# Altium schematic sheet XCore407I.SchDoc(XCore407I)
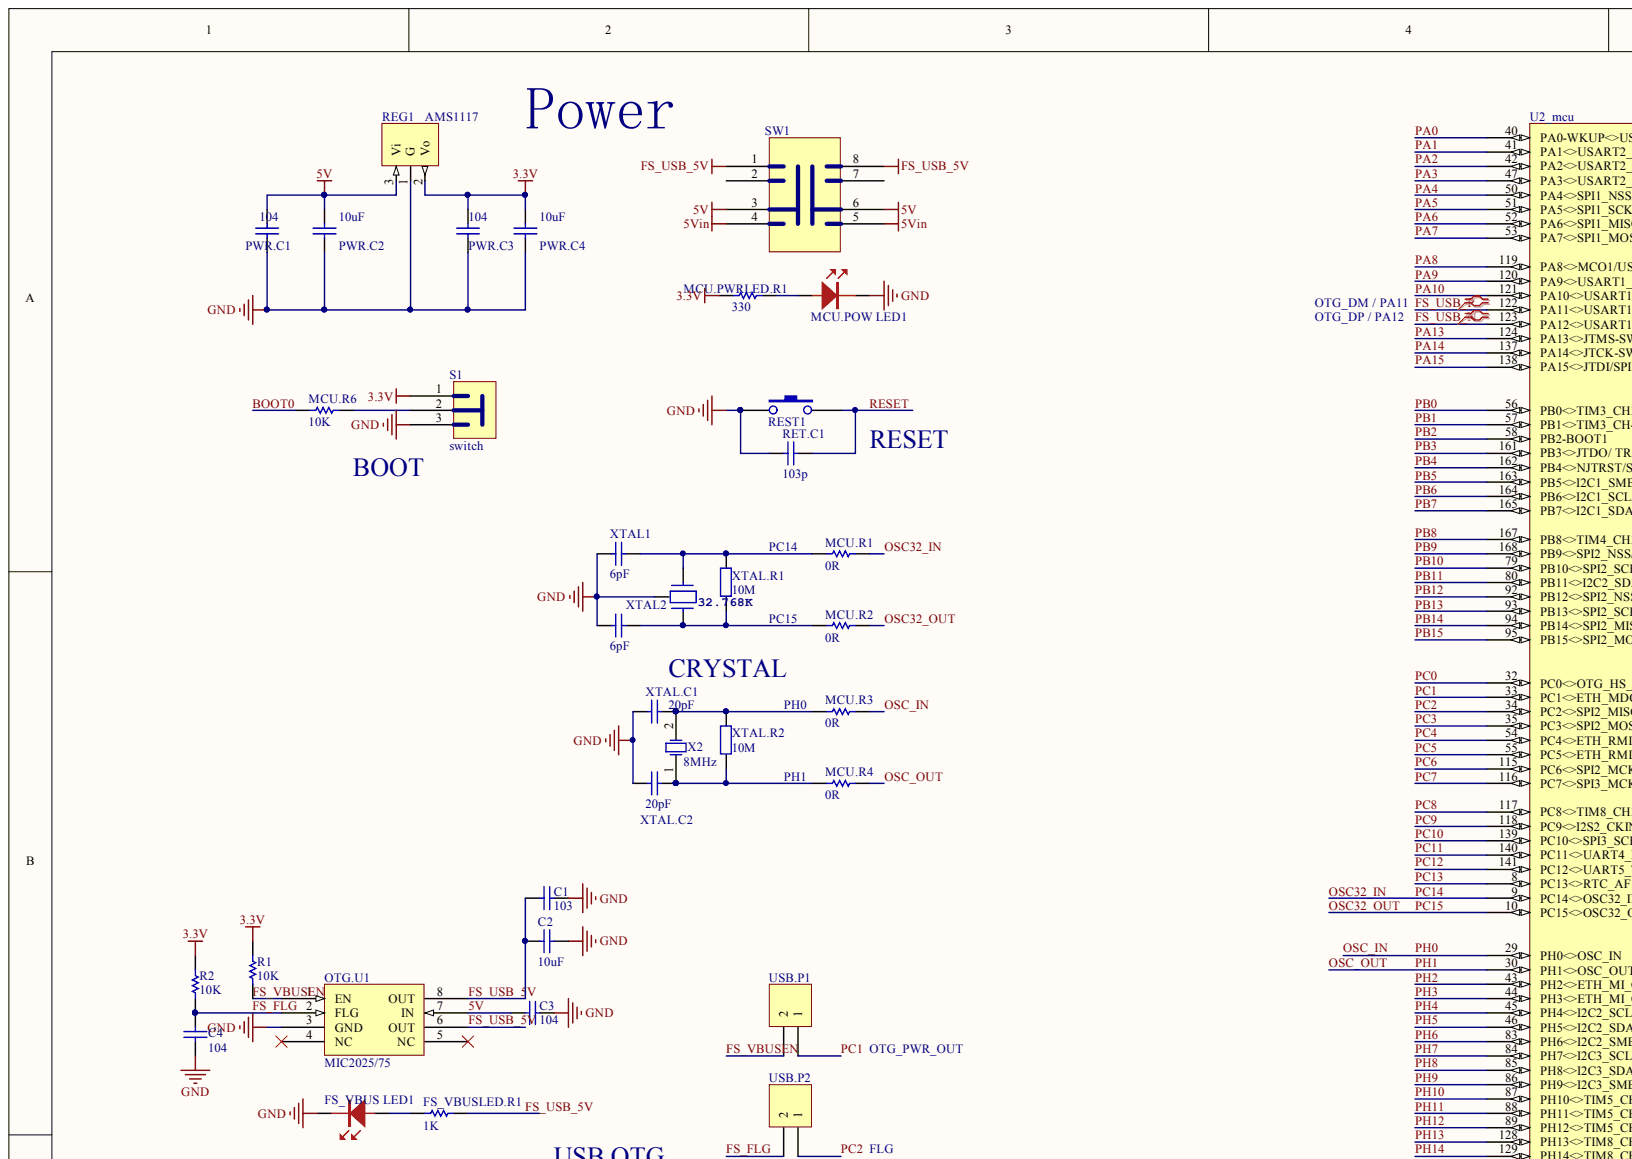
[diagram p0: XCore407I.SchDoc, top left]
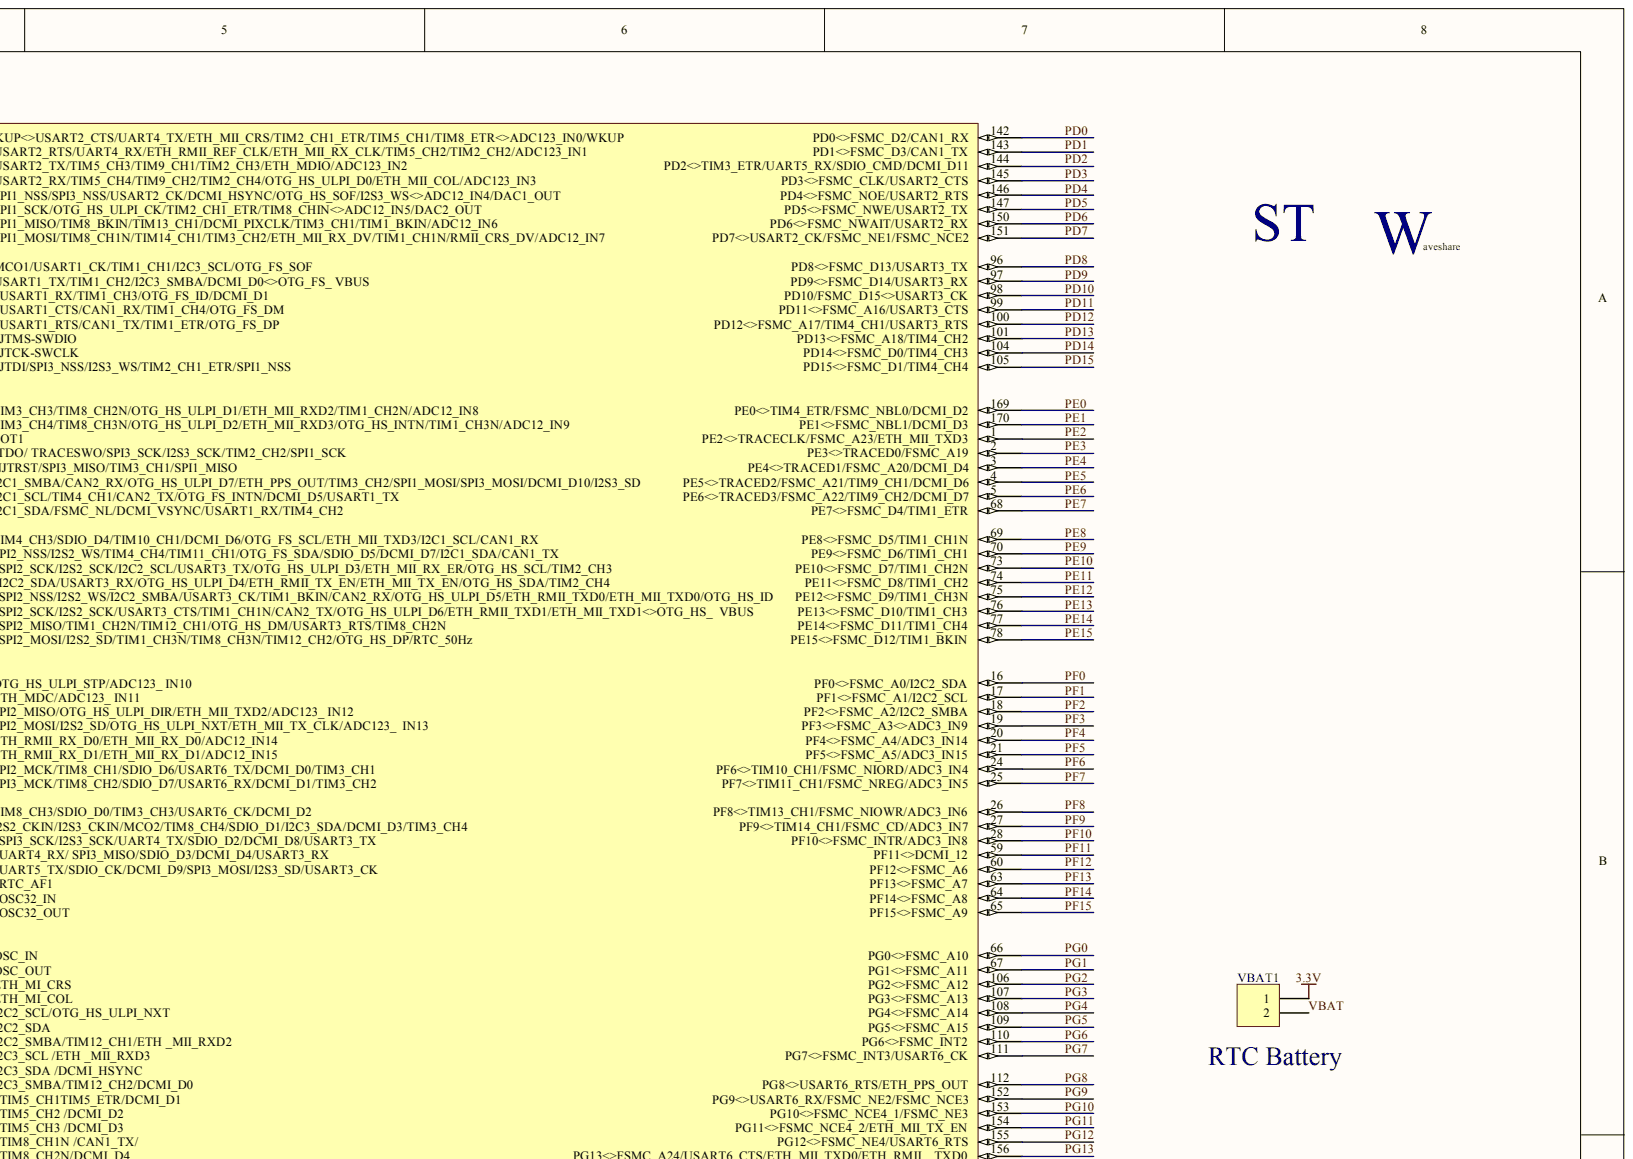
[diagram p1: XCore407I.SchDoc, top right]
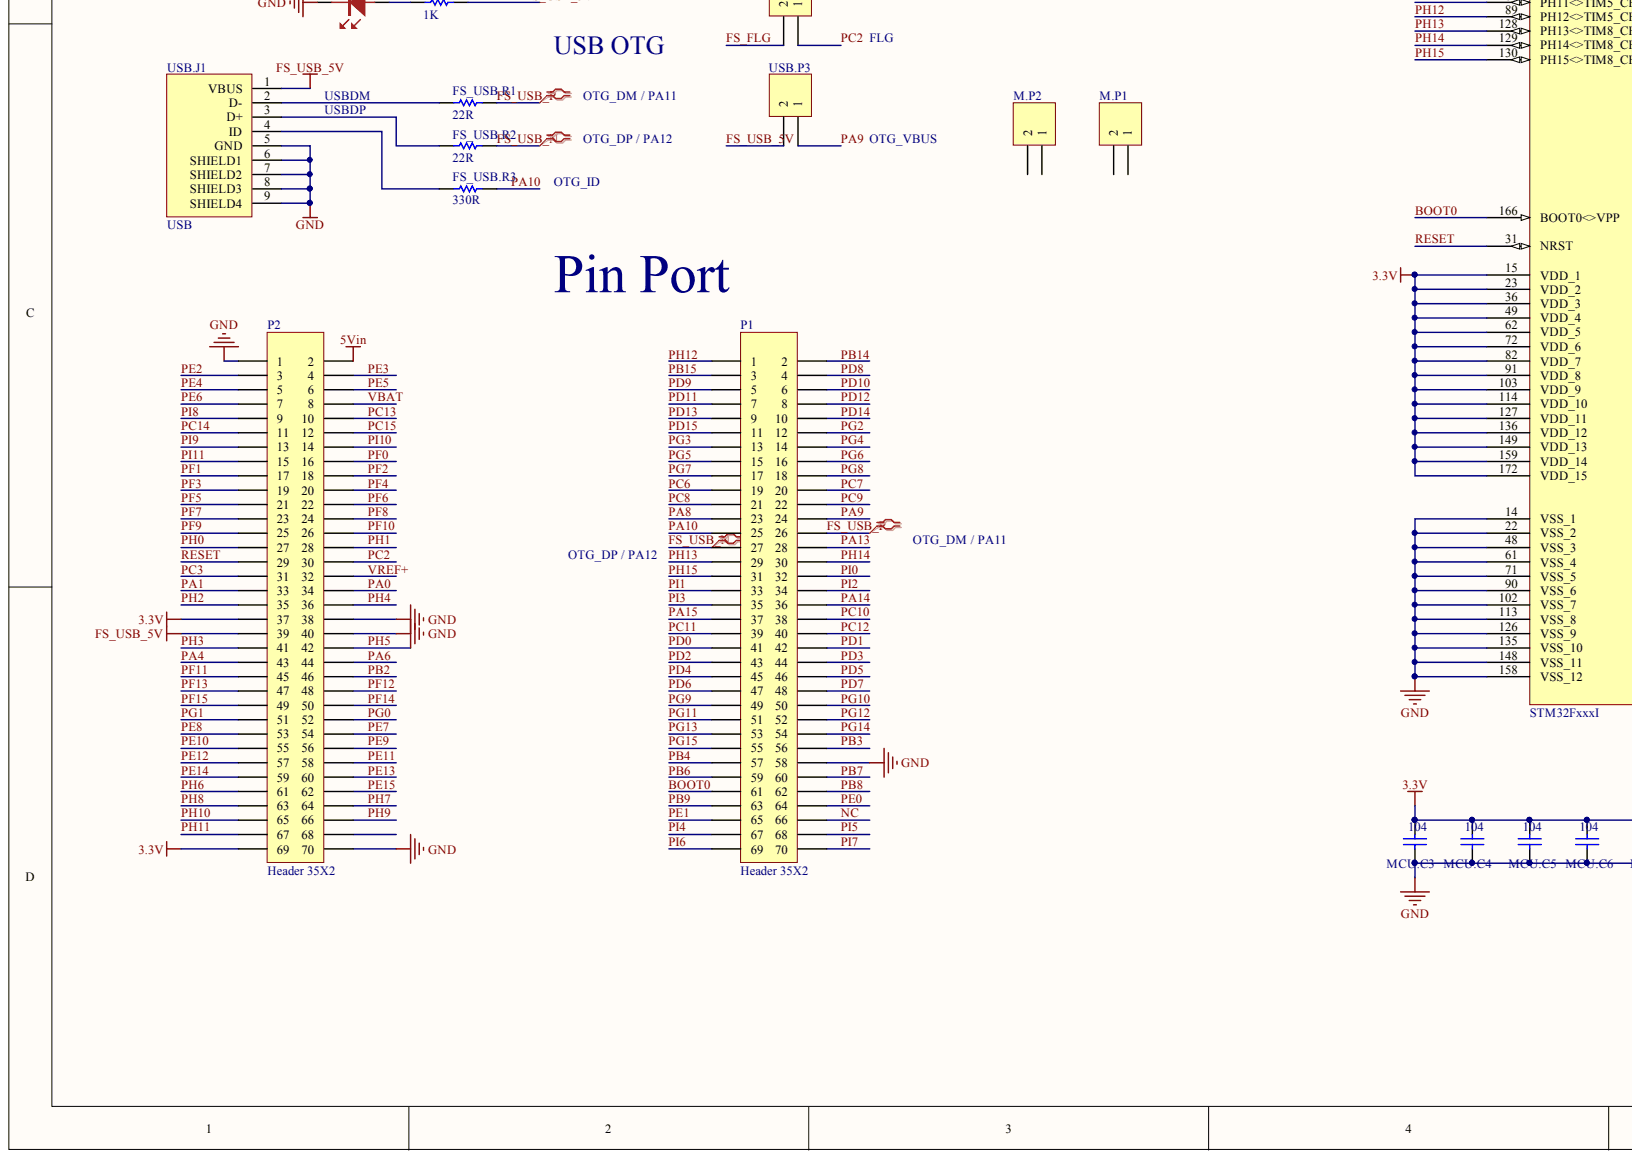
[diagram p2: XCore407I.SchDoc, bottom left]
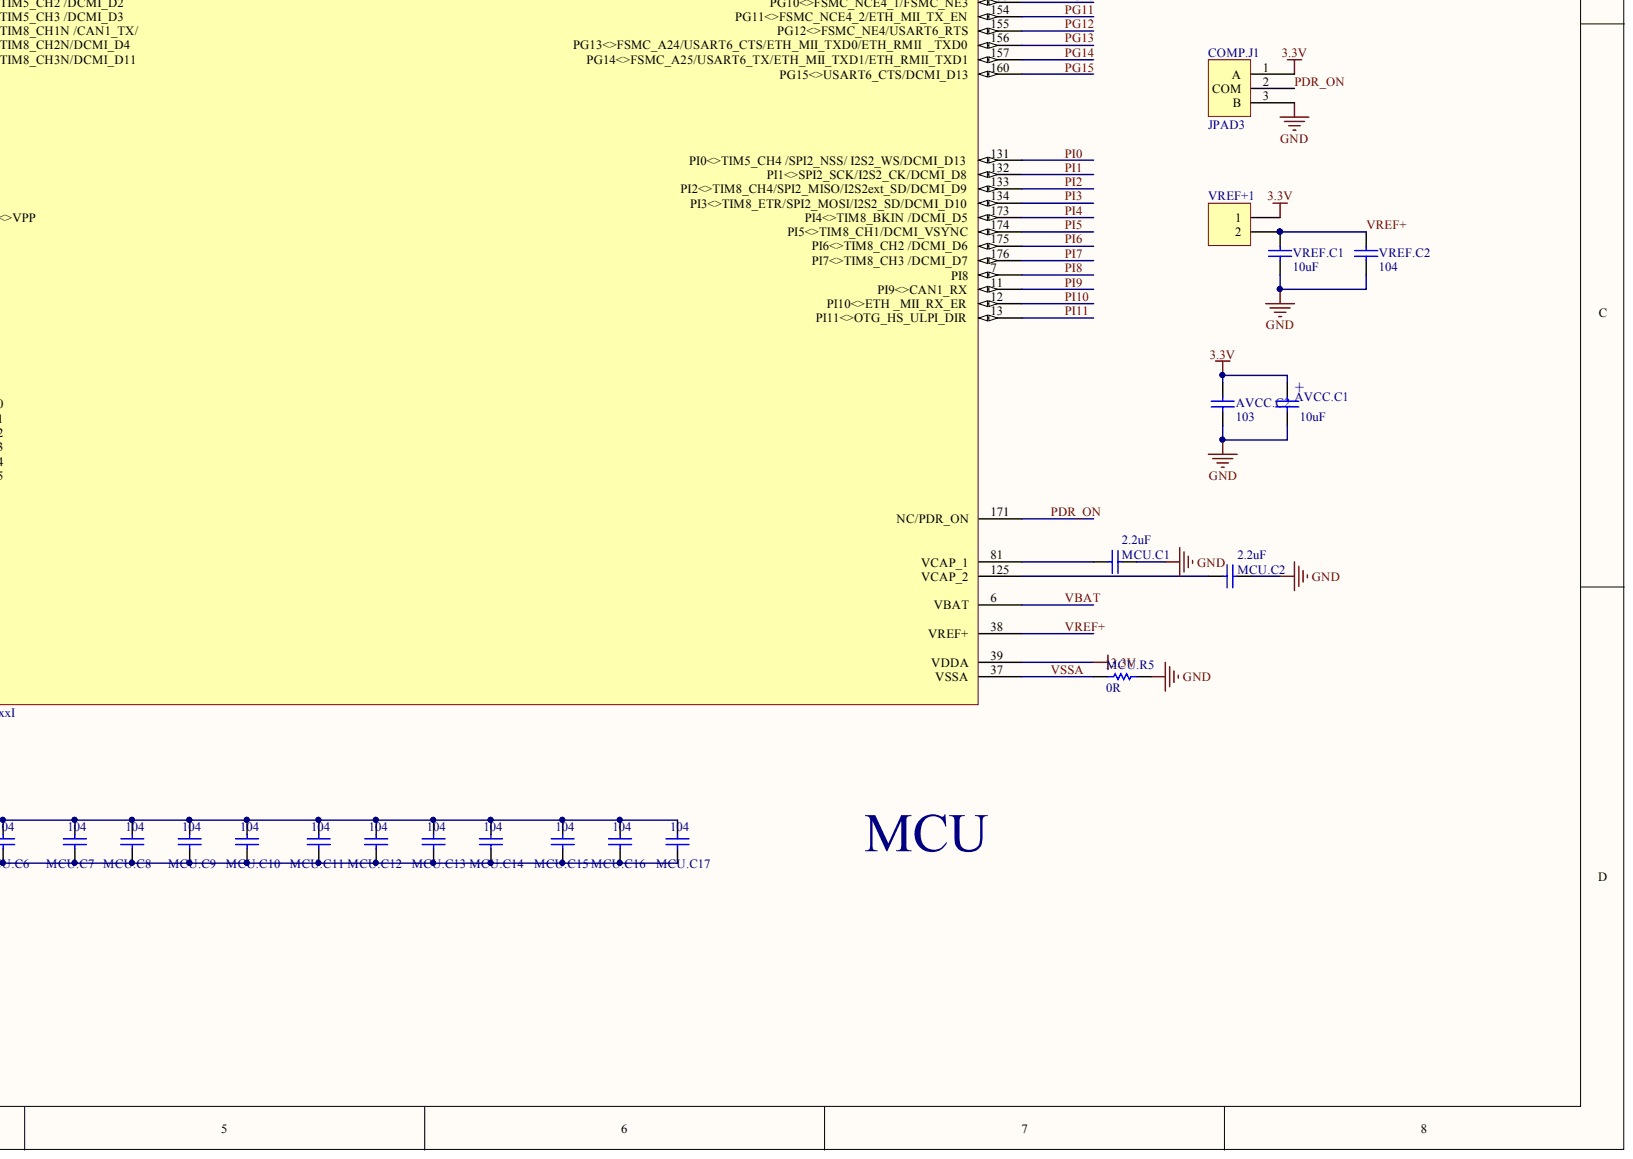
[diagram p3: XCore407I.SchDoc, bottom right]

## Parts
AVCC.C1  10uF
AVCC.C2  103
C1  103
C2  10uF
C3  104
C4  104
COMP.J1  JPAD3
FS_USB.R1  22R
FS_USB.R2  22R
FS_USB.R3  330R
FS_VBUS_LED1  LED_0805  (value not drawn)
FS_VBUSLED.R1  1K
M.P1  (no value)
M.P2  (no value)
MCU.C1  2.2uF
MCU.C2  2.2uF
MCU.C3  104
MCU.C4  104
MCU.C5  104
MCU.C6  104
MCU.C7  104
MCU.C8  104
MCU.C9  104
MCU.C10  104
MCU.C11  104
MCU.C12  104
MCU.C13  104
MCU.C14  104
MCU.C15  104
MCU.C16  104
MCU.C17  104
MCU.POW_LED1  LED_0805  (value not drawn)
MCU.PWRLED.R1  330
MCU.R1  0R
MCU.R2  0R
MCU.R3  0R
MCU.R4  0R
MCU.R5  0R
MCU.R6  10K
OTG.U1  MIC2025/75
P1  Header 35X2
P2  Header 35X2
PWR.C1  104
PWR.C2  10uF
PWR.C3  104
PWR.C4  10uF
R1  10K
R2  10K
REG1  AMS1117
REST1  KEY3  (value not drawn)
RET.C1  103p
S1  switch
ST1  ST-OGO  (value not drawn)
SW1  (no value)
U2_mcu  STM32FxxxI
USB.J1  USB
USB.P1  (no value)
USB.P2  (no value)
USB.P3  (no value)
VBAT1  (no value)
VREF+1  Header 2  (value not drawn)
VREF.C1  10uF
VREF.C2  104
w1  waveshare_logo  (value not drawn)
X1  32.768K
X2  8MHz
XTAL1  6pF
XTAL2  6pF
XTAL.C1  20pF
XTAL.C2  20pF
XTAL.R1  value 10M  (hidden comment: Res2)
XTAL.R2  value 10M  (hidden comment: Res2)

## Nets
3.3V: AVCC.C1.1 AVCC.C2.1 COMP.J1.1 MCU.C3.2 MCU.C4.2 MCU.C5.2 MCU.C6.2 MCU.C7.2 MCU.C8.2 MCU.C9.2 MCU.C10.2 MCU.C11.2 MCU.C12.2 MCU.C13.2 MCU.C14.2 MCU.C15.2 MCU.C16.2 MCU.C17.2 MCU.PWRLED.R1.1 P2.37 P2.69 PWR.C3.2 PWR.C4.1 R1.1 R2.1 REG1.2 S1.1 U2_mcu.15(VDD_1) U2_mcu.23(VDD_2) U2_mcu.36(VDD_3) U2_mcu.39(VDDA) U2_mcu.49(VDD_4) U2_mcu.62(VDD_5) U2_mcu.72(VDD_6) U2_mcu.82(VDD_7) U2_mcu.91(VDD_8) U2_mcu.103(VDD_9) U2_mcu.114(VDD_10) U2_mcu.127(VDD_11) U2_mcu.136(VDD_12) U2_mcu.149(VDD_13) U2_mcu.159(VDD_14) U2_mcu.172(VDD_15) VBAT1.1 VREF+1.1
5VIN: P2.2 SW1.4 SW1.5
GND: AVCC.C1.2 AVCC.C2.2 C1.2 C2.2 C3.1 C4.2 COMP.J1.3 FS_VBUS_LED1.2 MCU.C1.1 MCU.C2.1 MCU.C3.1 MCU.C4.1 MCU.C5.1 MCU.C6.1 MCU.C7.1 MCU.C8.1 MCU.C9.1 MCU.C10.1 MCU.C11.1 MCU.C12.1 MCU.C13.1 MCU.C14.1 MCU.C15.1 MCU.C16.1 MCU.C17.1 MCU.POW_LED1.2 MCU.R5.1 OTG.U1.3(GND) P1.58 P2.1 P2.38 P2.40 P2.70 PWR.C1.2 PWR.C2.2 PWR.C3.1 PWR.C4.2 REG1.1 REST1.1 RET.C1.1 S1.3 U2_mcu.14(VSS_1) U2_mcu.22(VSS_2) U2_mcu.48(VSS_3) U2_mcu.61(VSS_4) U2_mcu.71(VSS_5) U2_mcu.90(VSS_6) U2_mcu.102(VSS_7) U2_mcu.113(VSS_8) U2_mcu.126(VSS_9) U2_mcu.135(VSS_10) U2_mcu.148(VSS_11) U2_mcu.158(VSS_12) USB.J1.5(GND) USB.J1.6(SHIELD1) USB.J1.7(SHIELD2) USB.J1.8(SHIELD3) USB.J1.9(SHIELD4) VREF.C1.2 VREF.C2.1 X1.3 XTAL1.1 XTAL2.1 XTAL.C1.1 XTAL.C2.1
5V: C3.2 OTG.U1.7(IN) PWR.C1.1 PWR.C2.1 REG1.3 SW1.3 SW1.6
BOOT0: MCU.R6.2 P1.61 U2_mcu.166(BOOT0<>VPP)
FS_FLG: C4.1 OTG.U1.2(FLG) R2.2 USB.P2.2
FS_USB_5V: C1.1 C2.1 FS_VBUSLED.R1.1 OTG.U1.6(OUT) OTG.U1.8(OUT) P2.39 SW1.1 SW1.8 USB.J1.1(VBUS) USB.P3.2
FS_USB_N: FS_USB.R2.2 P1.27 U2_mcu.123(PA12<>USART1_RTS/CAN1_TX/TIM1_ETR/OTG_FS_DP)
FS_USB_P: FS_USB.R1.2 P1.26 U2_mcu.122(PA11<>USART1_CTS/CAN1_RX/TIM1_CH4/OTG_FS_DM)
FS_VBUSEN: OTG.U1.1(EN) R1.2 USB.P1.2
NC: P1.66
OSC32_IN: MCU.R1.1 P2.11 U2_mcu.9(PC14<>OSC32_IN)
OSC32_OUT: MCU.R2.1 P2.12 U2_mcu.10(PC15<>OSC32_OUT)
OSC_IN: MCU.R3.1 P2.27 U2_mcu.29(PH0<>OSC_IN)
OSC_OUT: MCU.R4.1 P2.28 U2_mcu.30(PH1<>OSC_OUT)
PA0: P2.34 U2_mcu.40(PA0-WKUP<>USART2_CTS/UART4_TX/ETH_MII_CRS/TIM2_CH1_ETR/TIM5_CH1/TIM8_ETR<>ADC123_IN0/WKUP)
PA1: P2.33 U2_mcu.41(PA1<>USART2_RTS/UART4_RX/ETH_RMII_REF_CLK/ETH_MII_RX_CLK/TIM5_CH2/TIM2_CH2/ADC123_IN1)
PA2: U2_mcu.42(PA2<>USART2_TX/TIM5_CH3/TIM9_CH1/TIM2_CH3/ETH_MDIO/ADC123_IN2)
PA3: U2_mcu.47(PA3<>USART2_RX/TIM5_CH4/TIM9_CH2/TIM2_CH4/OTG_HS_ULPI_D0/ETH_MII_COL/ADC123_IN3)
PA4: P2.43 U2_mcu.50(PA4<>SPI1_NSS/SPI3_NSS/USART2_CK/DCMI_HSYNC/OTG_HS_SOF/I2S3_WS<>ADC12_IN4/DAC1_OUT)
PA5: U2_mcu.51(PA5<>SPI1_SCK/OTG_HS_ULPI_CK/TIM2_CH1_ETR/TIM8_CHIN<>ADC12_IN5/DAC2_OUT)
PA6: P2.44 U2_mcu.52(PA6<>SPI1_MISO/TIM8_BKIN/TIM13_CH1/DCMI_PIXCLK/TIM3_CH1/TIM1_BKIN/ADC12_IN6)
PA7: U2_mcu.53(PA7<>SPI1_MOSI/TIM8_CH1N/TIM14_CH1/TIM3_CH2/ETH_MII_RX_DV/TIM1_CH1N/RMII_CRS_DV/ADC12_IN7)
PA8: P1.23 U2_mcu.119(PA8<>MCO1/USART1_CK/TIM1_CH1/I2C3_SCL/OTG_FS_SOF)
PA9: P1.24 U2_mcu.120(PA9<>USART1_TX/TIM1_CH2/I2C3_SMBA/DCMI_D0<>OTG_FS__VBUS) USB.P3.1
PA10: FS_USB.R3.2 P1.25 U2_mcu.121(PA10<>USART1_RX/TIM1_CH3/OTG_FS_ID/DCMI_D1)
PA13: P1.28 U2_mcu.124(PA13<>JTMS-SWDIO)
PA14: P1.36 U2_mcu.137(PA14<>JTCK-SWCLK)
PA15: P1.37 U2_mcu.138(PA15<>JTDI/SPI3_NSS/I2S3_WS/TIM2_CH1_ETR/SPI1_NSS)
PB0: U2_mcu.56(PB0<>TIM3_CH3/TIM8_CH2N/OTG_HS_ULPI_D1/ETH_MII_RXD2/TIM1_CH2N/ADC12_IN8)
PB1: U2_mcu.57(PB1<>TIM3_CH4/TIM8_CH3N/OTG_HS_ULPI_D2/ETH_MII_RXD3/OTG_HS_INTN/TIM1_CH3N/ADC12_IN9)
PB2: P2.46 U2_mcu.58(PB2-BOOT1)
PB3: P1.56 U2_mcu.161(PB3<>JTDO/_TRACESWO/SPI3_SCK/I2S3_SCK/TIM2_CH2/SPI1_SCK)
PB4: P1.57 U2_mcu.162(PB4<>NJTRST/SPI3_MISO/TIM3_CH1/SPI1_MISO)
PB5: U2_mcu.163(PB5<>I2C1_SMBA/CAN2_RX/OTG_HS_ULPI_D7/ETH_PPS_OUT/TIM3_CH2/SPI1_MOSI/SPI3_MOSI/DCMI_D10/I2S3_SD)
PB6: P1.59 U2_mcu.164(PB6<>I2C1_SCL/TIM4_CH1/CAN2_TX/OTG_FS_INTN/DCMI_D5/USART1_TX)
PB7: P1.60 U2_mcu.165(PB7<>I2C1_SDA/FSMC_NL/DCMI_VSYNC/USART1_RX/TIM4_CH2)
PB8: P1.62 U2_mcu.167(PB8<>TIM4_CH3/SDIO_D4/TIM10_CH1/DCMI_D6/OTG_FS_SCL/ETH_MII_TXD3/I2C1_SCL/CAN1_RX)
PB9: P1.63 U2_mcu.168(PB9<>SPI2_NSS/I2S2_WS/TIM4_CH4/TIM11_CH1/OTG_FS_SDA/SDIO_D5/DCMI_D7/I2C1_SDA/CAN1_TX)
PB10: U2_mcu.79(PB10<>SPI2_SCK/I2S2_SCK/I2C2_SCL/USART3_TX/OTG_HS_ULPI_D3/ETH_MII_RX_ER/OTG_HS_SCL/TIM2_CH3)
PB11: U2_mcu.80(PB11<>I2C2_SDA/USART3_RX/OTG_HS_ULPI_D4/ETH_RMII_TX_EN/ETH_MII_TX_EN/OTG_HS_SDA/TIM2_CH4)
PB12: U2_mcu.92(PB12<>SPI2_NSS/I2S2_WS/I2C2_SMBA/USART3_CK/TIM1_BKIN/CAN2_RX/OTG_HS_ULPI_D5/ETH_RMII_TXD0/ETH_MII_TXD0/OTG_HS_ID)
PB13: U2_mcu.93(PB13<>SPI2_SCK/I2S2_SCK/USART3_CTS/TIM1_CH1N/CAN2_TX/OTG_HS_ULPI_D6/ETH_RMII_TXD1/ETH_MII_TXD1<>OTG_HS_)
PB14: P1.2 U2_mcu.94(PB14<>SPI2_MISO/TIM1_CH2N/TIM12_CH1/OTG_HS_DM/USART3_RTS/TIM8_CH2N)
PB15: P1.3 U2_mcu.95(PB15<>SPI2_MOSI/I2S2_SD/TIM1_CH3N/TIM8_CH3N/TIM12_CH2/OTG_HS_DP/RTC_50Hz)
PC0: U2_mcu.32(PC0<>OTG_HS_ULPI_STP/ADC123__IN10)
PC1: U2_mcu.33(PC1<>ETH_MDC/ADC123__IN11) USB.P1.1
PC2: P2.30 U2_mcu.34(PC2<>SPI2_MISO/OTG_HS_ULPI_DIR/ETH_MII_TXD2/ADC123__IN12) USB.P2.1
PC3: P2.31 U2_mcu.35(PC3<>SPI2_MOSI/I2S2_SD/OTG_HS_ULPI_NXT/ETH_MII_TX_CLK/ADC123_)
PC4: U2_mcu.54(PC4<>ETH_RMII_RX_D0/ETH_MII_RX_D0/ADC12_IN14)
PC5: U2_mcu.55(PC5<>ETH_RMII_RX_D1/ETH_MII_RX_D1/ADC12_IN15)
PC6: P1.19 U2_mcu.115(PC6<>SPI2_MCK/TIM8_CH1/SDIO_D6/USART6_TX/DCMI_D0/TIM3_CH1)
PC7: P1.20 U2_mcu.116(PC7<>SPI3_MCK/TIM8_CH2/SDIO_D7/USART6_RX/DCMI_D1/TIM3_CH2)
PC8: P1.21 U2_mcu.117(PC8<>TIM8_CH3/SDIO_D0/TIM3_CH3/USART6_CK/DCMI_D2)
PC9: P1.22 U2_mcu.118(PC9<>I2S2_CKIN/I2S3_CKIN/MCO2/TIM8_CH4/SDIO_D1/I2C3_SDA/DCMI_D3/TIM3_CH4)
PC10: P1.38 U2_mcu.139(PC10<>SPI3_SCK/I2S3_SCK/UART4_TX/SDIO_D2/DCMI_D8/USART3_TX)
PC11: P1.39 U2_mcu.140(PC11<>UART4_RX/_SPI3_MISO/SDIO_D3/DCMI_D4/USART3_RX)
PC12: P1.40 U2_mcu.141(PC12<>UART5_TX/SDIO_CK/DCMI_D9/SPI3_MOSI/I2S3_SD/USART3_CK)
PC13: P2.10 U2_mcu.8(PC13<>RTC_AF1)
PD0: P1.41 U2_mcu.142(PD0<>FSMC_D2/CAN1_RX)
PD1: P1.42 U2_mcu.143(PD1<>FSMC_D3/CAN1_TX)
PD2: P1.43 U2_mcu.144(PD2<>TIM3_ETR/UART5_RX/SDIO_CMD/DCMI_D11)
PD3: P1.44 U2_mcu.145(PD3<>FSMC_CLK/USART2_CTS)
PD4: P1.45 U2_mcu.146(PD4<>FSMC_NOE/USART2_RTS)
PD5: P1.46 U2_mcu.147(PD5<>FSMC_NWE/USART2_TX)
PD6: P1.47 U2_mcu.150(PD6<>FSMC_NWAIT/USART2_RX)
PD7: P1.48 U2_mcu.151(PD7<>USART2_CK/FSMC_NE1/FSMC_NCE2)
PD8: P1.4 U2_mcu.96(PD8<>FSMC_D13/USART3_TX)
PD9: P1.5 U2_mcu.97(PD9<>FSMC_D14/USART3_RX)
PD10: P1.6 U2_mcu.98(PD10/FSMC_D15<>USART3_CK)
PD11: P1.7 U2_mcu.99(PD11<>FSMC_A16/USART3_CTS)
PD12: P1.8 U2_mcu.100(PD12<>FSMC_A17/TIM4_CH1/USART3_RTS)
PD13: P1.9 U2_mcu.101(PD13<>FSMC_A18/TIM4_CH2)
PD14: P1.10 U2_mcu.104(PD14<>FSMC_D0/TIM4_CH3)
PD15: P1.11 U2_mcu.105(PD15<>FSMC_D1/TIM4_CH4)
PDR_ON: COMP.J1.2 U2_mcu.171(NC/PDR_ON)
PE0: P1.64 U2_mcu.169(PE0<>TIM4_ETR/FSMC_NBL0/DCMI_D2)
PE1: P1.65 U2_mcu.170(PE1<>FSMC_NBL1/DCMI_D3)
PE2: P2.3 U2_mcu.1(PE2<>TRACECLK/FSMC_A23/ETH_MII_TXD3)
PE3: P2.4 U2_mcu.2(PE3<>TRACED0/FSMC_A19)
PE4: P2.5 U2_mcu.3(PE4<>TRACED1/FSMC_A20/DCMI_D4)
PE5: P2.6 U2_mcu.4(PE5<>TRACED2/FSMC_A21/TIM9_CH1/DCMI_D6)
PE6: P2.7 U2_mcu.5(PE6<>TRACED3/FSMC_A22/TIM9_CH2/DCMI_D7)
PE7: P2.54 U2_mcu.68(PE7<>FSMC_D4/TIM1_ETR)
PE8: P2.53 U2_mcu.69(PE8<>FSMC_D5/TIM1_CH1N)
PE9: P2.56 U2_mcu.70(PE9<>FSMC_D6/TIM1_CH1)
PE10: P2.55 U2_mcu.73(PE10<>FSMC_D7/TIM1_CH2N)
PE11: P2.58 U2_mcu.74(PE11<>FSMC_D8/TIM1_CH2)
PE12: P2.57 U2_mcu.75(PE12<>FSMC_D9/TIM1_CH3N)
PE13: P2.60 U2_mcu.76(PE13<>FSMC_D10/TIM1_CH3)
PE14: P2.59 U2_mcu.77(PE14<>FSMC_D11/TIM1_CH4)
PE15: P2.62 U2_mcu.78(PE15<>FSMC_D12/TIM1_BKIN)
PF0: P2.16 U2_mcu.16(PF0<>FSMC_A0/I2C2_SDA)
PF1: P2.17 U2_mcu.17(PF1<>FSMC_A1/I2C2_SCL)
PF2: P2.18 U2_mcu.18(PF2<>FSMC_A2/I2C2_SMBA)
PF3: P2.19 U2_mcu.19(PF3<>FSMC_A3<>ADC3_IN9)
PF4: P2.20 U2_mcu.20(PF4<>FSMC_A4/ADC3_IN14)
PF5: P2.21 U2_mcu.21(PF5<>FSMC_A5/ADC3_IN15)
PF6: P2.22 U2_mcu.24(PF6<>TIM10_CH1/FSMC_NIORD/ADC3_IN4)
PF7: P2.23 U2_mcu.25(PF7<>TIM11_CH1/FSMC_NREG/ADC3_IN5)
PF8: P2.24 U2_mcu.26(PF8<>TIM13_CH1/FSMC_NIOWR/ADC3_IN6)
PF9: P2.25 U2_mcu.27(PF9<>TIM14_CH1/FSMC_CD/ADC3_IN7)
PF10: P2.26 U2_mcu.28(PF10<>FSMC_INTR/ADC3_IN8)
PF11: P2.45 U2_mcu.59(PF11<>DCMI_12)
PF12: P2.48 U2_mcu.60(PF12<>FSMC_A6)
PF13: P2.47 U2_mcu.63(PF13<>FSMC_A7)
PF14: P2.50 U2_mcu.64(PF14<>FSMC_A8)
PF15: P2.49 U2_mcu.65(PF15<>FSMC_A9)
PG0: P2.52 U2_mcu.66(PG0<>FSMC_A10)
PG1: P2.51 U2_mcu.67(PG1<>FSMC_A11)
PG2: P1.12 U2_mcu.106(PG2<>FSMC_A12)
PG3: P1.13 U2_mcu.107(PG3<>FSMC_A13)
PG4: P1.14 U2_mcu.108(PG4<>FSMC_A14)
PG5: P1.15 U2_mcu.109(PG5<>FSMC_A15)
PG6: P1.16 U2_mcu.110(PG6<>FSMC_INT2)
PG7: P1.17 U2_mcu.111(PG7<>FSMC_INT3/USART6_CK)
PG8: P1.18 U2_mcu.112(PG8<>USART6_RTS/ETH_PPS_OUT)
PG9: P1.49 U2_mcu.152(PG9<>USART6_RX/FSMC_NE2/FSMC_NCE3)
PG10: P1.50 U2_mcu.153(PG10<>FSMC_NCE4_1/FSMC_NE3)
PG11: P1.51 U2_mcu.154(PG11<>FSMC_NCE4_2/ETH_MII_TX_EN)
PG12: P1.52 U2_mcu.155(PG12<>FSMC_NE4/USART6_RTS)
PG13: P1.53 U2_mcu.156(_TXD0)
PG14: P1.54 U2_mcu.157(PG14<>FSMC_A25/USART6_TX/ETH_MII_TXD1/ETH_RMII_TXD1)
PG15: P1.55 U2_mcu.160(PG15<>USART6_CTS/DCMI_D13)
PH2: P2.35 U2_mcu.43(PH2<>ETH_MI_CRS)
PH3: P2.41 U2_mcu.44(PH3<>ETH_MI_COL)
PH4: P2.36 U2_mcu.45(PH4<>I2C2_SCL/OTG_HS_ULPI_NXT)
PH5: P2.42 U2_mcu.46(PH5<>I2C2_SDA)
PH6: P2.61 U2_mcu.83(PH6<>I2C2_SMBA/TIM12_CH1/ETH__MII_RXD2)
PH7: P2.64 U2_mcu.84(PH7<>I2C3_SCL_/ETH__MII_RXD3)
PH8: P2.63 U2_mcu.85(PH8<>I2C3_SDA_/DCMI_HSYNC)
PH9: P2.66 U2_mcu.86(PH9<>I2C3_SMBA/TIM12_CH2/DCMI_D0)
PH10: P2.65 U2_mcu.87(PH10<>TIM5_CH1TIM5_ETR/DCMI_D1)
PH11: P2.67 U2_mcu.88(PH11<>TIM5_CH2_/DCMI_D2)
PH12: P1.1 U2_mcu.89(PH12<>TIM5_CH3_/DCMI_D3)
PH13: P1.29 U2_mcu.128(PH13<>TIM8_CH1N_/CAN1_TX/)
PH14: P1.30 U2_mcu.129(PH14<>TIM8_CH2N/DCMI_D4)
PH15: P1.31 U2_mcu.130(PH15<>TIM8_CH3N/DCMI_D11)
PI0: P1.32 U2_mcu.131(PI0<>TIM5_CH4_/SPI2_NSS/_I2S2_WS/DCMI_D13)
PI1: P1.33 U2_mcu.132(PI1<>SPI2_SCK/I2S2_CK/DCMI_D8)
PI2: P1.34 U2_mcu.133(PI2<>TIM8_CH4/SPI2_MISO/I2S2ext_SD/DCMI_D9)
PI3: P1.35 U2_mcu.134(PI3<>TIM8_ETR/SPI2_MOSI/I2S2_SD/DCMI_D10)
PI4: P1.67 U2_mcu.173(PI4<>TIM8_BKIN_/DCMI_D5)
PI5: P1.68 U2_mcu.174(PI5<>TIM8_CH1/DCMI_VSYNC)
PI6: P1.69 U2_mcu.175(PI6<>TIM8_CH2_/DCMI_D6)
PI7: P1.70 U2_mcu.176(PI7<>TIM8_CH3_/DCMI_D7)
PI8: P2.9 U2_mcu.7(PI8)
PI9: P2.13 U2_mcu.11(PI9<>CAN1_RX)
PI10: P2.14 U2_mcu.12(PI10<>ETH__MII_RX_ER)
PI11: P2.15 U2_mcu.13(PI11<>OTG_HS_ULPI_DIR)
RESET: P2.29 REST1.2 RET.C1.2 U2_mcu.31(NRST)
VBAT: P2.8 U2_mcu.6(VBAT) VBAT1.2
VREF+: P2.32 U2_mcu.38(VREF+) VREF+1.2 VREF.C1.1 VREF.C2.2
VSSA: MCU.R5.2 U2_mcu.37(VSSA)
NetFS_USB.R1_1: FS_USB.R1.1 USB.J1.2(D-)
NetFS_USB.R2_1: FS_USB.R2.1 USB.J1.3(D+)
NetFS_USB.R3_1: FS_USB.R3.1 USB.J1.4(ID)
NetFS_VBUS LED1_1: FS_VBUS_LED1.1 FS_VBUSLED.R1.2
NetMCU.C1_2: MCU.C1.2 U2_mcu.81(VCAP_1)
NetMCU.C2_2: MCU.C2.2 U2_mcu.125(VCAP_2)
NetMCU.POW LED1_1: MCU.POW_LED1.1 MCU.PWRLED.R1.2
NetMCU.R1_2: MCU.R1.2 X1.1 XTAL1.2 XTAL.R1.2
NetMCU.R2_2: MCU.R2.2 X1.2 XTAL2.2 XTAL.R1.1
NetMCU.R3_2: MCU.R3.2 X2.2 XTAL.C1.2 XTAL.R2.2
NetMCU.R4_2: MCU.R4.2 X2.1 XTAL.C2.2 XTAL.R2.1
NetMCU.R6_1: MCU.R6.1 S1.2

Not connected: M.P1 1 2; M.P2 1 2; OTG.U1 4(NC) 5(NC); P2 68; SW1 2 7

## Library data of the parts
C1 C3 C4 = (no symbol name): Value: 100pF
FS_USB.R1-FS_USB.R3 MCU.PWRLED.R1 MCU.R1-MCU.R6 R1 R2 = (no symbol name): Value: 1K
  FS_USB.R1-FS_USB.R3 MCU.R1-MCU.R6 R1 R2: Published: 8-Jun-2000; LatestRevisionDate: 17-Jul-2002; LatestRevisionNote: Re-released for DXP Platform.; PackageReference: AXIAL-0.3; Publisher: Altium Limited
MCU.C1 MCU.C2 = (no symbol name): Value: 104
XTAL1 XTAL2 = (no symbol name): Value: 20pF
AVCC.C1 AVCC.C2 C2 COMP.J1 FS_VBUS_LED1 FS_VBUSLED.R1 M.P1 M.P2 MCU.C3-MCU.C17 MCU.POW_LED1 OTG.U1 P1 P2 PWR.C1-PWR.C4 REG1 REST1 RET.C1 S1 ST1 SW1 U2_mcu USB.J1 USB.P1-USB.P3 VBAT1 VREF+1 VREF.C1 VREF.C2 w1 X1 X2 XTAL.C1 XTAL.C2 XTAL.R1 XTAL.R2 = (no symbol name)
  AVCC.C1 C2 PWR.C2 PWR.C4 VREF.C1: Value: 10uF
  FS_VBUSLED.R1: Value: 1K
  M.P1 M.P2 USB.P1-USB.P3 VBAT1 VREF+1: LatestRevisionDate: 17-Jul-2002; LatestRevisionNote: Re-released for DXP Platform.
  MCU.C3-MCU.C17 PWR.C1 PWR.C3 VREF.C2: Value: 104
  RET.C1: Value: 103p
  X2: Published: 8-Jun-2000; LatestRevisionDate: 17-Jul-2002; LatestRevisionNote: Re-released for DXP Platform.; PackageReference: R38
  XTAL.C1 XTAL.C2: Value: 20pF
  XTAL.R1 XTAL.R2: Published: 8-Jun-2000; LatestRevisionDate: 17-Jul-2002; LatestRevisionNote: Re-released for DXP Platform.; PackageReference: AXIAL-0.4
  M.P1 M.P2 USB.P1-USB.P3 VBAT1 VREF+1 X2 XTAL.R1 XTAL.R2: Publisher: Altium Limited
